# Altium schematic sheet TX_Sys.SchDoc(TX_Sys)
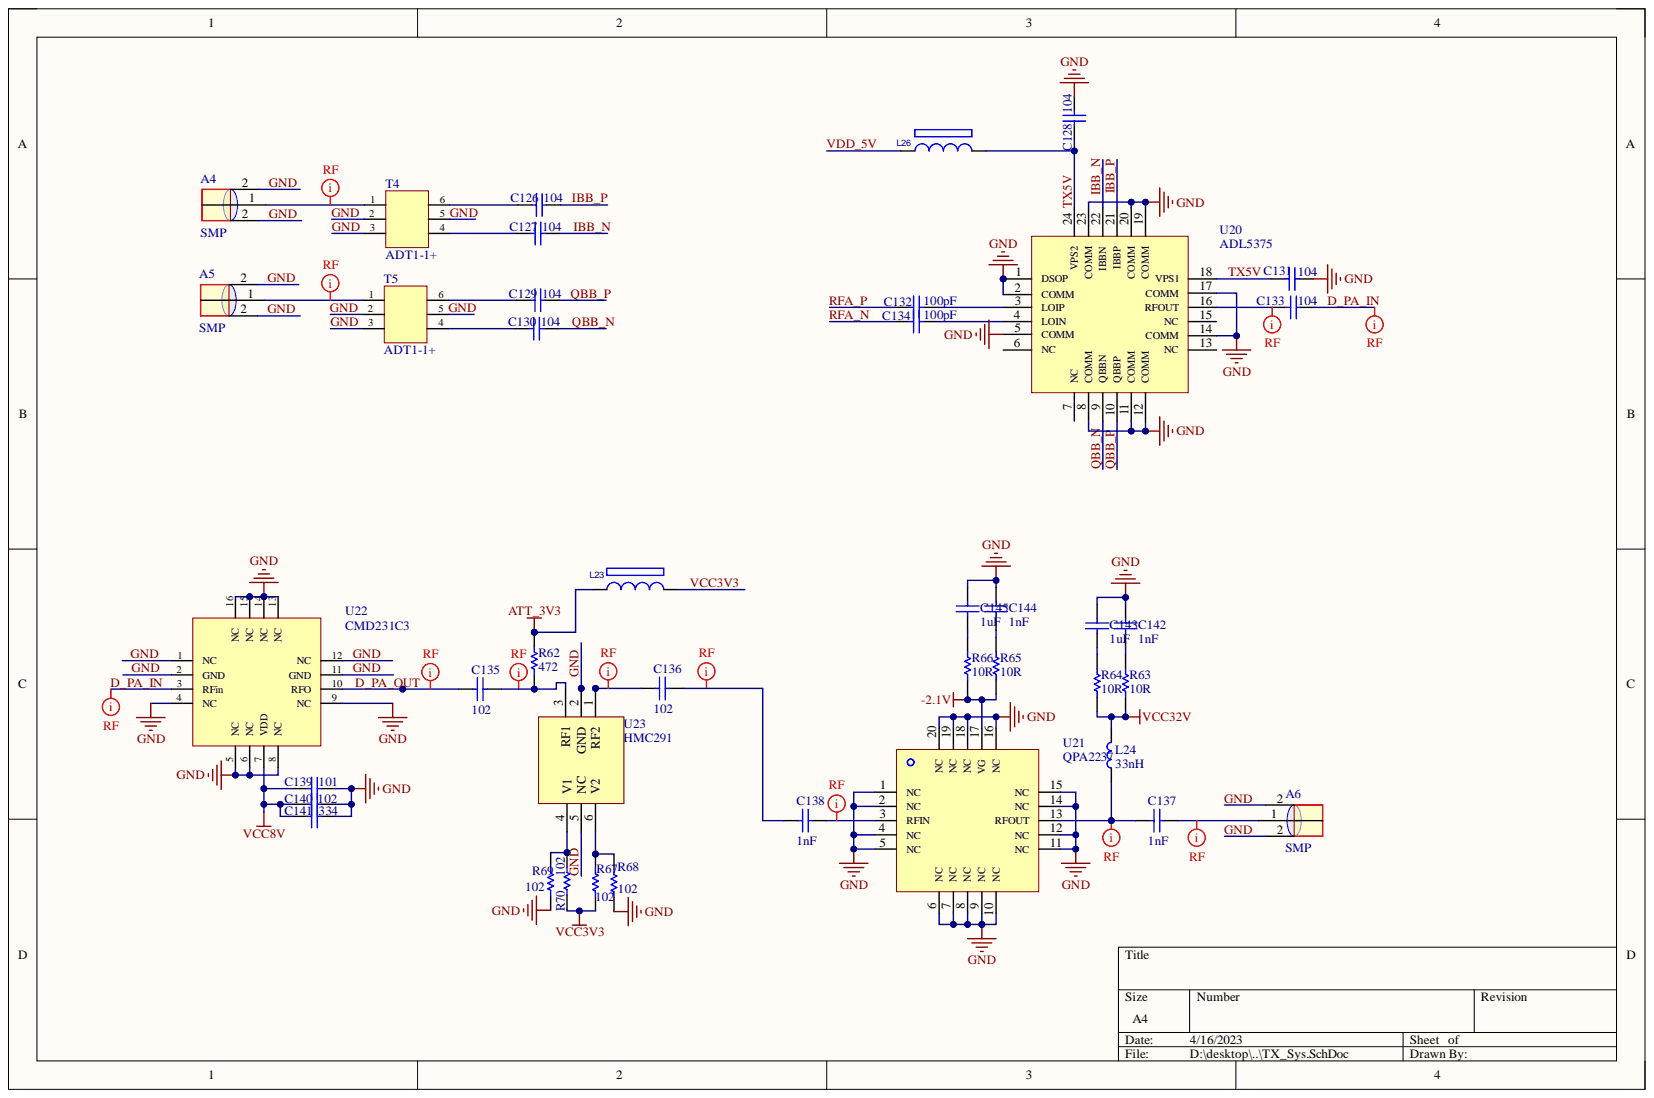
[diagram p0: TX_Sys.SchDoc]

## Parts
A4  SMP  [SMA_3P]
A5  SMP  [SMA_3P]
A6  SMP  [SMA_3P]
C126  104  [0402C]
C127  104  [0402C]
C128  104  [0402C]
C129  104  [0402C]
C130  104  [0402C]
C131  104  [0402C]
C132  100pF  [0402C]
C133  104  [0402C]
C134  100pF  [0402C]
C135  102  [0402C]
C136  102  [0402C]
C137  1nF  [0402C]
C138  1nF  [0402C]
C139  101  [0402C]
C140  102  [0402C]
C141  334  [0402C]
C142  1nF  [0402C]
C143  1uF  [0402C]
C144  1nF  [0402C]
C145  1uF  [0402C]
L23  BLM15HG102SN1D  [0603L]  (value not drawn)
L24  33nH  [L 0805]
L26  BLM15HG102SN1D  [0603L]  (value not drawn)
R62  472  [0402R]
R63  10R  [0402R]
R64  10R  [0402R]
R65  10R  [0402R]
R66  10R  [0402R]
R67  102  [0402R]
R68  102  [0402R]
R69  102  [0402R]
R70  102  [0402R]
T4  ADT1-1+  [ADT1-1+]
T5  ADT1-1+  [ADT1-1+]
U20  ADL5375  [QFN24 _MMIC]
U21  QPA2237  [QFN20]
U22  CMD231C3  [QFN16]
U23  HMC291  [SOT23-6]

## Nets
-2.1V: R65.2 R66.2 U21.17(VG)
ATT_3V3: L23.1 R62.2
VCC8V: C139.2 C140.2 C141.2 U22.7(VDD)
VCC32V: L24.2 R63.2 R64.2
D_PA_IN: C133.2 U22.3(RFin)
D_PA_OUT: C135.1 U22.10(RFO)
GND: A4.2 A5.2 A6.2 C128.2 C131.1 C139.1 C140.1 C141.1 C142.1 C143.1 C144.1 C145.1 R68.1 R69.1 T4.2 T4.3 T4.5 T5.2 T5.3 T5.5 U20.1(DSOP) U20.2(COMM) U20.5(COMM) U20.8(COMM) U20.11(COMM) U20.12(COMM) U20.14(COMM) U20.17(COMM) U20.19(COMM) U20.20(COMM) U20.23(COMM) U21.1(NC) U21.2(NC) U21.4(NC) U21.5(NC) U21.6(NC) U21.7(NC) U21.8(NC) U21.9(NC) U21.10(NC) U21.11(NC) U21.12(NC) U21.14(NC) U21.15(NC) U21.16(NC) U21.18(NC) U21.19(NC) U21.20(NC) U22.1(NC) U22.2(GND) U22.4(NC) U22.5(NC) U22.6(NC) U22.8(NC) U22.9(NC) U22.11(GND) U22.12(NC) U22.13(NC) U22.14(NC) U22.15(NC) U22.16(NC) U23.2(GND) U23.5(NC)
IBB_N: C127.2 U20.22(IBBN)
IBB_P: C126.2 U20.21(IBBP)
QBB_N: C130.2 U20.9(QBBN)
QBB_P: C129.2 U20.10(QBBP)
RFA_N: C134.1
RFA_P: C132.1
TX5V: C128.1 C131.2 L26.2 U20.18(VPS1) U20.24(VPS2)
VCC3V3: L23.2 R67.1 R70.1
VDD_5V: L26.1
NetA4_1: A4.1 T4.1
NetA5_1: A5.1 T5.1
NetA6_1: A6.1 C137.1
NetC126_1: C126.1 T4.6
NetC127_1: C127.1 T4.4
NetC129_1: C129.1 T5.6
NetC130_1: C130.1 T5.4
NetC132_2: C132.2 U20.3(LOIP)
NetC133_1: C133.1 U20.16(RFOUT)
NetC134_2: C134.2 U20.4(LOIN)
NetC135_2: C135.2 R62.1 U23.3(RF1)
NetC136_1: C136.1 U23.1(RF2)
NetC136_2: C136.2 C138.2
NetC137_2: C137.2 L24.1 U21.13(RFOUT)
NetC138_1: C138.1 U21.3(RFIN)
NetC142_2: C142.2 R63.1
NetC143_2: C143.2 R64.1
NetC144_2: C144.2 R65.1
NetC145_2: C145.2 R66.1
NetR67_2: R67.2 R68.2 U23.6(V2)
NetR69_2: R69.2 R70.2 U23.4(V1)

Not connected: U20 6(NC) 7(NC) 13(NC) 15(NC)

## Library data of the parts
A4-A6 = SMP: GNSS; Component Kind: Standard; Library Name: TR_LIB.SchLib
C126-C131 C133 C135 C136 C139-C141 = Cap: GNSS; Value: 100pF; Component Kind: Standard; LatestRevisionDate: 17-Jul-2002; LatestRevisionNote: Re-released for DXP Platform.; Library Name: GPS_Ant.SCHLIB; PackageDescription: Radial Cap, Thru-Hole; 2 Leads; 0.3 in Pin Spacing; PackageReference: RAD-0.3; Published: 8-Jun-2000; Signal Integrity: Cap; Simulation: CAP; Publisher: Altium Limited
C132 C134 = Cap: GNSS; Component Kind: Standard; LatestRevisionDate: 17-Jul-2002; LatestRevisionNote: Re-released for DXP Platform.; Library Name: GPS_Ant.SCHLIB; PackageDescription: Radial Cap, Thru-Hole; 2 Leads; 0.3 in Pin Spacing; PackageReference: RAD-0.3; Published: 8-Jun-2000; Signal Integrity: Cap; Simulation: CAP; Value: 100pF; Publisher: Altium Limited
C137 C138 C142-C145 = Cap: Capacitor; Component Kind: Standard; LatestRevisionDate: 17-Jul-2002; LatestRevisionNote: Re-released for DXP Platform.; Library Name: Miscellaneous Devices.IntLib; PackageDescription: Radial Cap, Thru-Hole; 2 Leads; 0.3 in Pin Spacing; PackageReference: RAD-0.3; Published: 8-Jun-2000; Signal Integrity: Cap; Simulation: CAP; Publisher: Altium Limited
L23 L26 = L: GNSS; Bottom: 40; Right: 20; Component Kind: Standard
L24 = Inductor: Code_IEC: 1005; Code_JEITA: 0402; Component Kind: Standard; Description: Inductor; LatestRevisionDate: 29-May-2009; LatestRevisionNote: IPC-7351 Footprint Added.; Library Name: TR_LIB.SchLib; PackageDescription: Chip Inductor; PackageReference: 0402-A; Published: 8-Jun-2000; Signal Integrity: Inductor; Simulation: INDUCTOR; Publisher: Altium Limited
R62 R67-R70 = Res1: GNSS; Component Kind: Standard; LatestRevisionDate: 17-Jul-2002; LatestRevisionNote: Re-released for DXP Platform.; Library Name: GPS_Ant.SCHLIB; PackageDescription: Axial Device, Thru-Hole; 2 Leads; 0.3 in Pin Spacing; PackageReference: AXIAL-0.3; Published: 8-Jun-2000; Signal Integrity: Res; Simulation: RESISTOR; Publisher: Altium Limited
R63-R66 = Res Semi: Semiconductor Resistor; Component Kind: Standard; LatestRevisionDate: 17-Jul-2002; LatestRevisionNote: Re-released for DXP Platform.; Library Name: Miscellaneous Devices.IntLib; PackageDescription: Axial Device, Thru-Hole; 2 Leads; 0.5 in Pin Spacing; PackageReference: AXIAL-0.5; Published: 8-Jun-2000; Signal Integrity: Res Semi; Simulation: RES; Publisher: Altium Limited
T4 T5 = ADT1-1+: Component Kind: Standard; Library Name: TR_LIB.SchLib
U20 = ADL5375: Component Kind: Standard; Library Name: TR_LIB.SchLib
U21 = QPA2237: Component Kind: Standard; Library Name: TR_LIB.SchLib
U22 = CMD231C3: Component Kind: Standard; Library Name: TR_LIB.SchLib
U23 = HMC291: Component Kind: Standard; Library Name: TR_LIB.SchLib
